# Altium schematic sheet 03_Seven_Seg.SchDoc
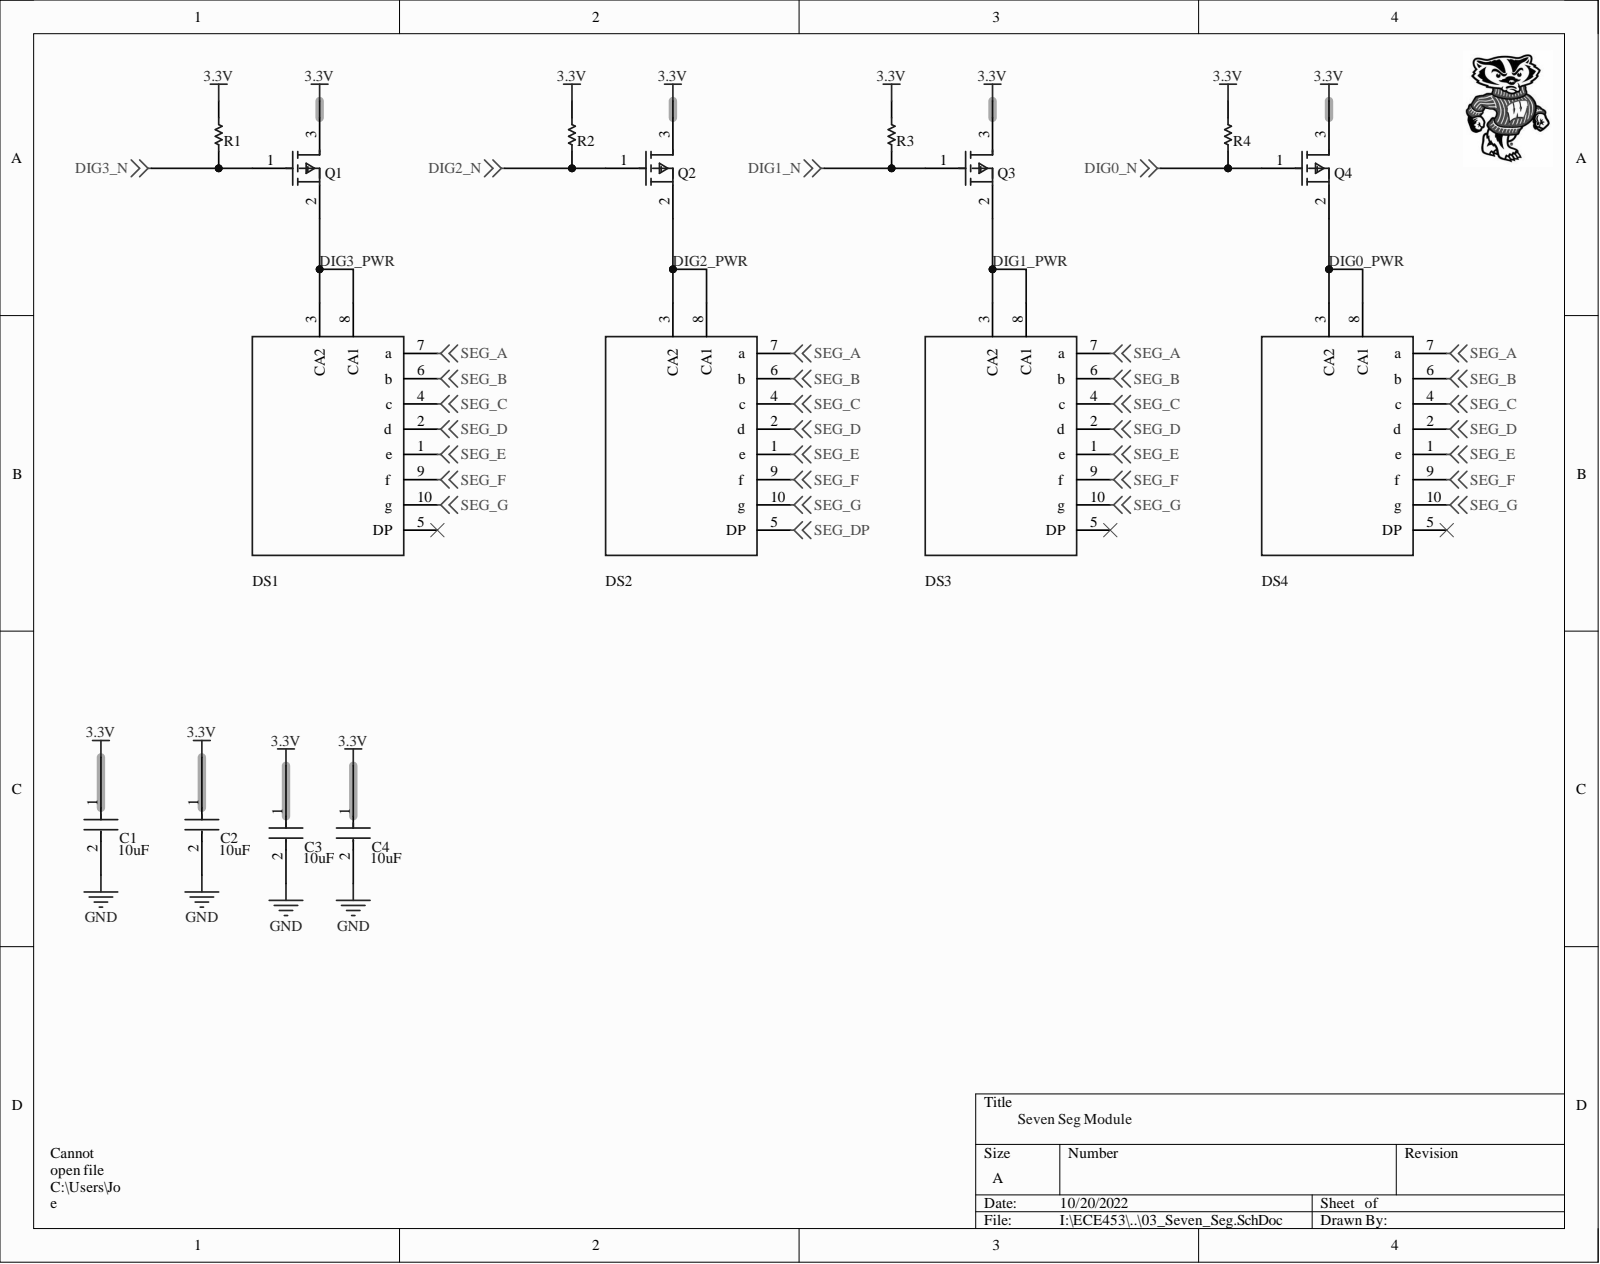
[diagram p0: 03_Seven_Seg.SchDoc]

## Parts
C1  Capacitance: 10uF  [FP-CL21-IPC_B]  (hidden comment: CL21A106KQCLNNC)
C2  Capacitance: 10uF  [FP-CL21-IPC_B]  (hidden comment: CL21A106KQCLNNC)
C3  Capacitance: 10uF  [FP-CL21-IPC_B]  (hidden comment: CL21A106KQCLNNC)
C4  Capacitance: 10uF  [FP-CL21-IPC_B]  (hidden comment: CL21A106KQCLNNC)
DS1  ACSA56-41CGKWA-F01  [ACSA56-41CGKWA-F01]  (value not drawn)
DS2  ACSA56-41CGKWA-F01  [ACSA56-41CGKWA-F01]  (value not drawn)
DS3  ACSA56-41CGKWA-F01  [ACSA56-41CGKWA-F01]  (value not drawn)
DS4  ACSA56-41CGKWA-F01  [ACSA56-41CGKWA-F01]  (value not drawn)
Q1  SSM3J133TU  [UFM_TOS]  (value not drawn)
Q2  SSM3J133TU  [UFM_TOS]  (value not drawn)
Q3  SSM3J133TU  [UFM_TOS]  (value not drawn)
Q4  SSM3J133TU  [UFM_TOS]  (value not drawn)
R1  RES_0805_K_010.00  [RES_0805]  (value not drawn)
R2  RES_0805_K_010.00  [RES_0805]  (value not drawn)
R3  RES_0805_K_010.00  [RES_0805]  (value not drawn)
R4  RES_0805_K_010.00  [RES_0805]  (value not drawn)

## Nets
3.3V: C1.1 C2.1 C3.1 C4.1 Q1.3 Q2.3 Q3.3 Q4.3 R1.2 R2.2 R3.2 R4.2
DIG0_N: Q4.1 R4.1
DIG1_N: Q3.1 R3.1
DIG2_N: Q2.1 R2.1
DIG3_N: Q1.1 R1.1
GND: C1.2 C2.2 C3.2 C4.2
SEG_A: DS1.7(a) DS2.7(a) DS3.7(a) DS4.7(a)
SEG_B: DS1.6(b) DS2.6(b) DS3.6(b) DS4.6(b)
SEG_C: DS1.4(c) DS2.4(c) DS3.4(c) DS4.4(c)
SEG_D: DS1.2(d) DS2.2(d) DS3.2(d) DS4.2(d)
SEG_DP: DS2.5(DP)
SEG_E: DS1.1(e) DS2.1(e) DS3.1(e) DS4.1(e)
SEG_F: DS1.9(f) DS2.9(f) DS3.9(f) DS4.9(f)
SEG_G: DS1.10(g) DS2.10(g) DS3.10(g) DS4.10(g)
DIG0_PWR: DS4.3(CA2) DS4.8(CA1) Q4.2
DIG1_PWR: DS3.3(CA2) DS3.8(CA1) Q3.2
DIG2_PWR: DS2.3(CA2) DS2.8(CA1) Q2.2
DIG3_PWR: DS1.3(CA2) DS1.8(CA1) Q1.2

Not connected: DS1 5(DP); DS3 5(DP); DS4 5(DP)

## Library data of the parts
C1-C4 = CMP-13271-003047-1: CL21 Series 0805 10uF 6.3V ±10% Tolerance X5R Multilayer Ceramic Chip Capacitor; Case Code (Imperial): 0805; Case Code (Metric): 2012; Case/Package: 0805; Component Kind: Standard; Depth: 1.25mm; Dielectric: X5R; Height: 0.85mm; Lead Free: Lead Free; Length: 2mm; Library Name: Altium Content Vault; Max Operating Temperature: 85°C; Min Operating Temperature: -55°C; Mount: Surface Mount; Package Quantity: 1; Packaging: Tape and Reel; Radiation Hardening: No; Thickness: 0.037inch; Tolerance: 10%; Voltage Rating: 6.3V; Width: 0.049inch
DS1-DS4 = ACSA56-41CGKWA-F01: DISPLAY 0.56" SGL 574NM GRN SMD; Manufacturer 1: Kingbright; Color: Green; Display Type: Segment; Element Configuration: Common Anode; Forward Current: 30mA; Forward Voltage: 2.1V; Luminous Intensity: 25mcd; Max Power Dissipation: 75mW; Number of Characters: 1; Test Current: 20mA; Wavelength: 570nm; Case/Package: SMD/SMT; Category: LED Displays; Component Kind: Standard; ComponentLink1Description: https://datasheet.ciiva.com/2103/acsa56-41cgkwa-f01-2103794.pdf?src-supplier=Digi-Key; ComponentLink1URL: https://datasheet.ciiva.com/2103/acsa56-41cgkwa-f01-2103794.pdf?src-supplier=Digi-Key; ComponentLink2Description: https://datasheet.ciiva.com/4421/acsa56-41cgkwa-f01-17964-4421697.pdf?src-supplier=Mouser; ComponentLink2URL: https://datasheet.ciiva.com/4421/acsa56-41cgkwa-f01-17964-4421697.pdf?src-supplier=Mouser; ComponentLink3Description: https://datasheet.ciiva.com/16287/acsa56-41cgkwa-f01-16287523.pdf?src-supplier=Future+Electronics; ComponentLink3URL: https://datasheet.ciiva.com/16287/acsa56-41cgkwa-f01-16287523.pdf?src-supplier=Future+Electronics; ComponentLink4Description: https://datasheet.ciiva.com/2103/acsa56-41cgkwa-f01-2103795.pdf?src-supplier=Digi-Key; ComponentLink4URL: https://datasheet.ciiva.com/2103/acsa56-41cgkwa-f01-2103795.pdf?src-supplier=Digi-Key; ComponentLink5Description: https://datasheet.ciiva.com/2103/acsa56-41cgkwa-f01-2103796.pdf?src-supplier=Digi-Key; ComponentLink5URL: https://datasheet.ciiva.com/2103/acsa56-41cgkwa-f01-2103796.pdf?src-supplier=Digi-Key; Height: 0.669inch; Illumination Color: Green; Lead Free: Lead Free; Library Name: team6.SCHLIB; Manufacturer Part Number 1: ACSA56-41CGKWA-F01; Max Operating Temperature: 85°C; Min Operating Temperature: -40°C; Operating Supply Voltage: 2.1V; Package Quantity: 400; Packaging: Rail/Tube; Power Consumption: 75mW; Pricing 1: 1=3.38000, 10=2.25300, 100=1.73360 (USD); RoHS: Yes; RoHS Compliant: Yes; Stock 1: 38128; Supplier 1: Digi-Key; Supplier Part Number 1: 754-1033-1-ND; Width: 0.488inch
Q1-Q4 = SSM3J133TU: MOSFET P-CH 20V 4.4A UFM; Mfr_Name: Toshiba Electronic Devices & Storage Corp.; Type: DEV; Component Kind: Standard; Digi-Key_Part_Number_1: SSM3J133TULFCT-ND; Digi-Key_Part_Number_2: SSM3J133TULFDKR-ND; Digi-Key_Part_Number_3: SSM3J133TULFTR-ND; Library Name: team6.SCHLIB; Manufacturer_Part_Number: SSM3J133TU; RefDes: RefDes; Supplier 1: Digi-Key; Supplier Part Number 1: SSM3J133TULFCT-ND; Copyright: Copyright (C) 2022 Ultra Librarian. All rights reserved.
R1-R4 = RES_0805_K_010.00: RES SMD 10K OHM 1% 1/8W 0805; Manufacturer 1: Yageo; Manufacturer Part Number 1: RC0805FR-0710KL; Max Power Dissipation: 125mW; Resistance: 10kR; Temperature Coefficient: 100ppm/°C; Voltage Rating: 150V; Case Code (Imperial): 0805; Case Code (Metric): 2012; Case/Package: 0805; Category: Chip SMD Resistors; Component Kind: Standard; ComponentLink1Description: https://datasheet.ciiva.com/11808/0900766b8106beab-11808151.pdf?src-supplier=RSComponents; ComponentLink1URL: https://datasheet.ciiva.com/11808/0900766b8106beab-11808151.pdf?src-supplier=RSComponents; ComponentLink2Description: https://datasheet.ciiva.com/12669/rc0805-12669688.pdf?src-supplier=Mouser; ComponentLink2URL: https://datasheet.ciiva.com/12669/rc0805-12669688.pdf?src-supplier=Mouser; ComponentLink3Description: https://datasheet.ciiva.com/46447/444164-46447563.pdf?src-supplier=Element14; ComponentLink3URL: https://datasheet.ciiva.com/46447/444164-46447563.pdf?src-supplier=Element14; ComponentLink4Description: https://datasheet.ciiva.com/2456/pyu-r-int-thick-7-2456972.pdf?src-supplier=Digi-Key; ComponentLink4URL: https://datasheet.ciiva.com/2456/pyu-r-int-thick-7-2456972.pdf?src-supplier=Digi-Key; ComponentLink5Description: https://datasheet.ciiva.com/2456/pyu-r-int-thick-7-2456973.pdf?src-supplier=Digi-Key; ComponentLink5URL: https://datasheet.ciiva.com/2456/pyu-r-int-thick-7-2456973.pdf?src-supplier=Digi-Key; ComponentLink6Description: https://datasheet.ciiva.com/2456/pyu-r-int-thick-7-2456974.pdf?src-supplier=Digi-Key; ComponentLink6URL: https://datasheet.ciiva.com/2456/pyu-r-int-thick-7-2456974.pdf?src-supplier=Digi-Key; ComponentLink7Description: https://datasheet.ciiva.com/16747/rc0805-16747087.pdf?src-supplier=Future+Electronics; ComponentLink7URL: https://datasheet.ciiva.com/16747/rc0805-16747087.pdf?src-supplier=Future+Electronics; Construction: Rectangular; Depth: 1.25mm; Features: Moisture Resistant; Height: 0.024inch; Lead Free: Lead Free; Length: 2mm; Library Name: team6.SCHLIB; Max Operating Temperature: 155°C; Military Standard: Not; Min Operating Temperature: -55°C; Number of Terminations: 2; Package Quantity: 1; Packaging: Tape and Reel; Power Rating: 125mW; Pricing 1: 1=0.10000, 10=0.04200, 100=0.01690, 1000=0.00759, 2500=0.00659 (USD); REACH SVHC: Unknown; Resistance Tolerance: 1%; Resistor Type: Thick Film; RoHS: Yes; RoHS Compliant: Yes; Series: RC; Size Code: 0805; Stock 1: 7562122; Supplier 1: Digi-Key; Supplier Part Number 1: 311-10.0KCRCT-ND; Technology: THICK FILM; Termination: SMD/SMT; Tolerance: 1%; Width: 0.049inch
